# Altium schematic sheet RainbowClock.SchDoc
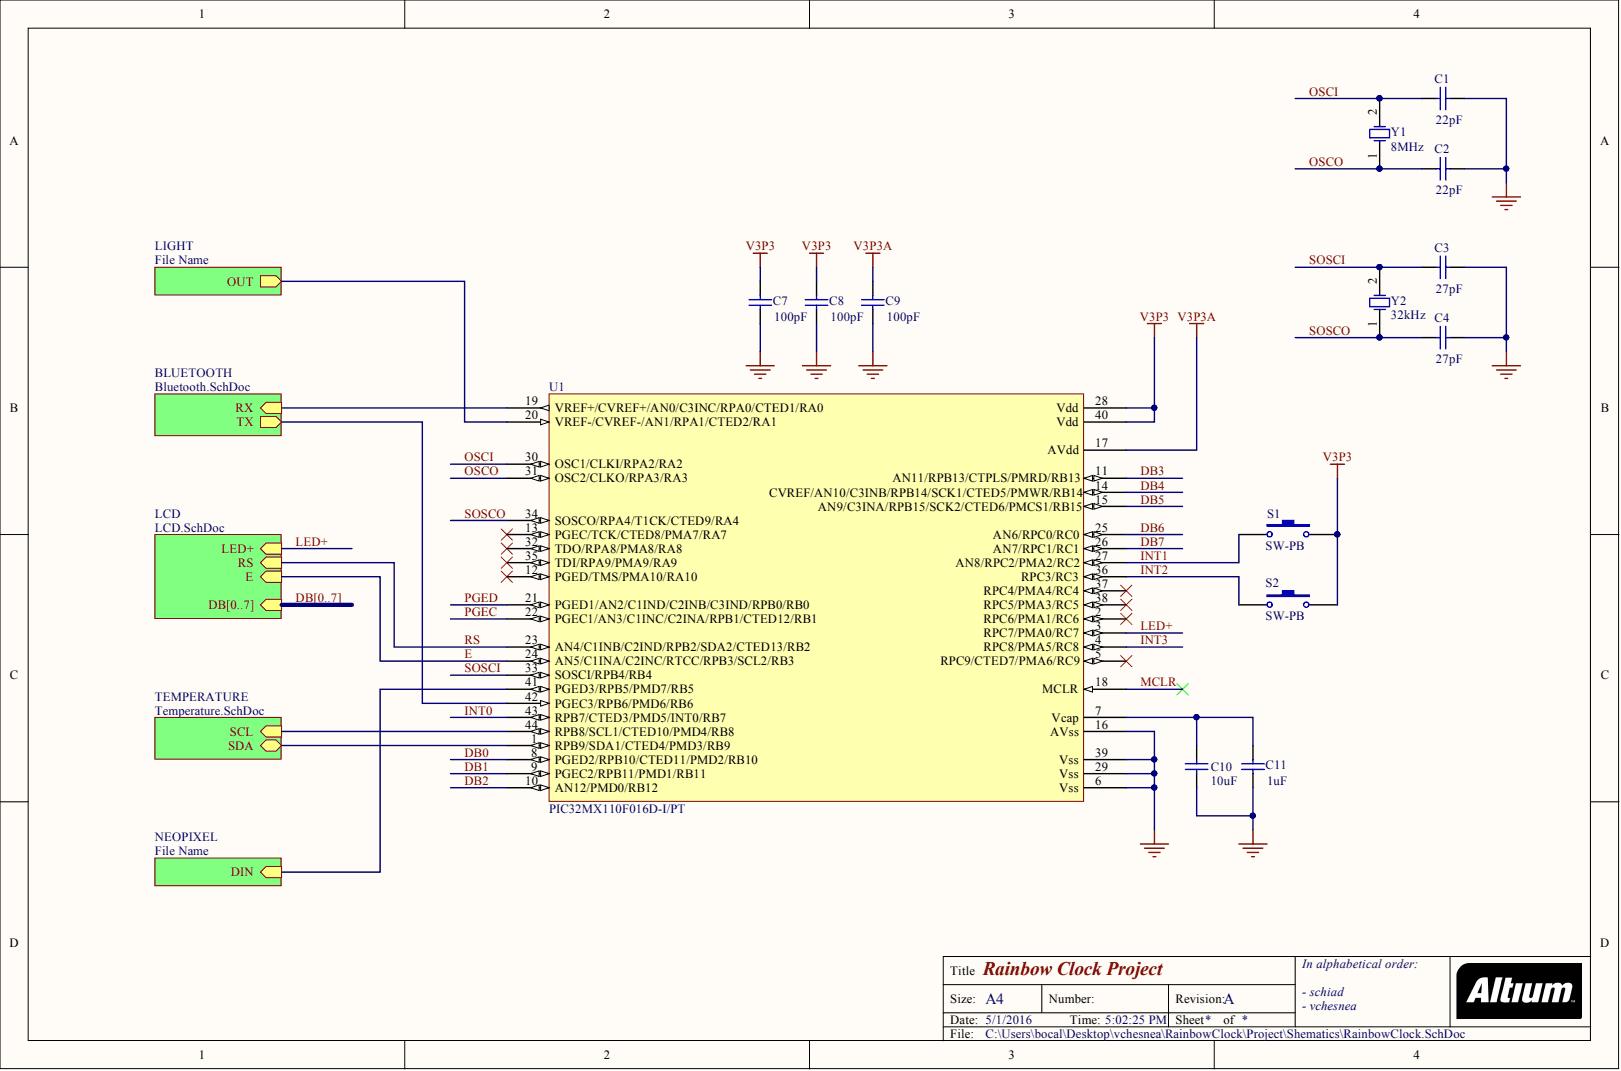
[diagram p0: RainbowClock.SchDoc]

## Parts
C1  value 22pF  [RAD-0.3]  (hidden comment: Cap)
C2  value 22pF  [RAD-0.3]  (hidden comment: Cap)
C3  value 27pF  [RAD-0.3]  (hidden comment: Cap)
C4  value 27pF  [RAD-0.3]  (hidden comment: Cap)
C7  value 100pF  [RAD-0.3]  (hidden comment: Cap)
C8  value 100pF  [RAD-0.3]  (hidden comment: Cap)
C9  value 100pF  [RAD-0.3]  (hidden comment: Cap)
C10  value 10uF  [RAD-0.3]  (hidden comment: Cap)
C11  value 1uF  [RAD-0.3]  (hidden comment: Cap)
S1  SW-PB  [SPST-2]
S2  SW-PB  [SPST-2]
U1  PIC32MX110F016D-I/PT  (value not drawn)
Y1  8MHz  [R38]
Y2  32kHz  [R38]

## Nets
GND: C1.2 C2.2 C3.2 C4.2 C7.1 C8.1 C9.1
V3P3: C7.2 C8.2 S1.2 S2.2 U1.28(Vdd) U1.40(Vdd)
V3P3A: C9.2 U1.17(AVdd)
VSS: C10.1 C11.1 U1.6(Vss) U1.16(AVss) U1.29(Vss) U1.39(Vss)
DB0: U1.8(PGED2/RPB10/CTED11/PMD2/RB10)  [also labelled DB]
DB1: U1.9(PGEC2/RPB11/PMD1/RB11)  [also labelled DB]
DB2: U1.10(AN12/PMD0/RB12)  [also labelled DB]
DB3: U1.11(AN11/RPB13/CTPLS/PMRD/RB13)  [also labelled DB]
DB4: U1.14(CVREF/AN10/C3INB/RPB14/SCK1/CTED5/PMWR/RB14)  [also labelled DB]
DB5: U1.15(AN9/C3INA/RPB15/SCK2/CTED6/PMCS1/RB15)  [also labelled DB]
DB6: U1.25(AN6/RPC0/RC0)  [also labelled DB]
DB7: U1.26(AN7/RPC1/RC1)  [also labelled DB]
E: U1.24(AN5/C1INA/C2INC/RTCC/RPB3/SCL2/RB3)
INT0: U1.43(RPB7/CTED3/PMD5/INT0/RB7)
INT1: S1.1 U1.27(AN8/RPC2/PMA2/RC2)
INT2: S2.1 U1.36(RPC3/RC3)
INT3: U1.4(RPC8/PMA5/RC8)
LED+: U1.3(RPC7/PMA0/RC7)
MCLR: U1.18(MCLR)
OSCI: C1.1 U1.30(OSC1/CLKI/RPA2/RA2) Y1.2
OSCO: C2.1 U1.31(OSC2/CLKO/RPA3/RA3) Y1.1
PGEC: U1.22(PGEC1/AN3/C1INC/C2INA/RPB1/CTED12/RB1)
PGED: U1.21(PGED1/AN2/C1IND/C2INB/C3IND/RPB0/RB0)
RS: U1.23(AN4/C1INB/C2IND/RPB2/SDA2/CTED13/RB2)
SOSCI: C3.1 U1.33(SOSCI/RPB4/RB4) Y2.2
SOSCO: C4.1 U1.34(SOSCO/RPA4/T1CK/CTED9/RA4) Y2.1
NetC10_2: C10.2 C11.2 U1.7(Vcap)
NetU1_1: U1.1(RPB9/SDA1/CTED4/PMD3/RB9)
NetU1_2: U1.2(RPC6/PMA1/RC6)
NetU1_5: U1.5(RPC9/CTED7/PMA6/RC9)
NetU1_12: U1.12(PGED/TMS/PMA10/RA10)
NetU1_13: U1.13(PGEC/TCK/CTED8/PMA7/RA7)
NetU1_19: U1.19(VREF+/CVREF+/AN0/C3INC/RPA0/CTED1/RA0)
NetU1_20: U1.20(VREF-/CVREF-/AN1/RPA1/CTED2/RA1)
NetU1_32: U1.32(TDO/RPA8/PMA8/RA8)
NetU1_35: U1.35(TDI/RPA9/PMA9/RA9)
NetU1_37: U1.37(RPC4/PMA4/RC4)
NetU1_38: U1.38(RPC5/PMA3/RC5)
NetU1_41: U1.41(PGED3/RPB5/PMD7/RB5)
NetU1_42: U1.42(PGEC3/RPB6/PMD6/RB6)
NetU1_44: U1.44(RPB8/SCL1/CTED10/PMD4/RB8)

## Library data of the parts
C1-C4 C7-C11 = Cap: Capacitor; Component Kind: Standard; LatestRevisionDate: 17-Jul-2002; LatestRevisionNote: Re-released for DXP Platform.; Library Name: Miscellaneous Devices.IntLib; PackageDescription: Radial Cap, Thru-Hole; 2 Leads; 0.3 in Pin Spacing; PackageReference: RAD-0.3; Published: 8-Jun-2000; Signal Integrity: Cap; Simulation: CAP; Publisher: Altium Limited
S1 S2 = SW-PB: Switch; Component Kind: Standard; LatestRevisionDate: 17-Jul-2002; LatestRevisionNote: Re-released for DXP Platform.; Library Name: Miscellaneous Devices.IntLib; PackageDescription: Switch; 2 Leads; PackageReference: SPST-2; Published: 24-Mar-1999; Publisher: Altium Limited
U1 = PIC32MX110F016D-I/PT: Microcontroller; Component Kind: Standard; Library Name: Components.SchLib
Y1 Y2 = XTAL: Crystal Oscillator; Component Kind: Standard; LatestRevisionDate: 17-Jul-2002; LatestRevisionNote: Re-released for DXP Platform.; Library Name: Miscellaneous Devices.IntLib; PackageDescription: Crystal, Thru-Hole; 2 Leads; Body 3.1 x 8.2 mm (Dia.xH); PackageReference: R38; Published: 8-Jun-2000; Simulation: XTAL; Publisher: Altium Limited
